# Altium schematic sheet Ethernet.SchDoc(Ethernet)
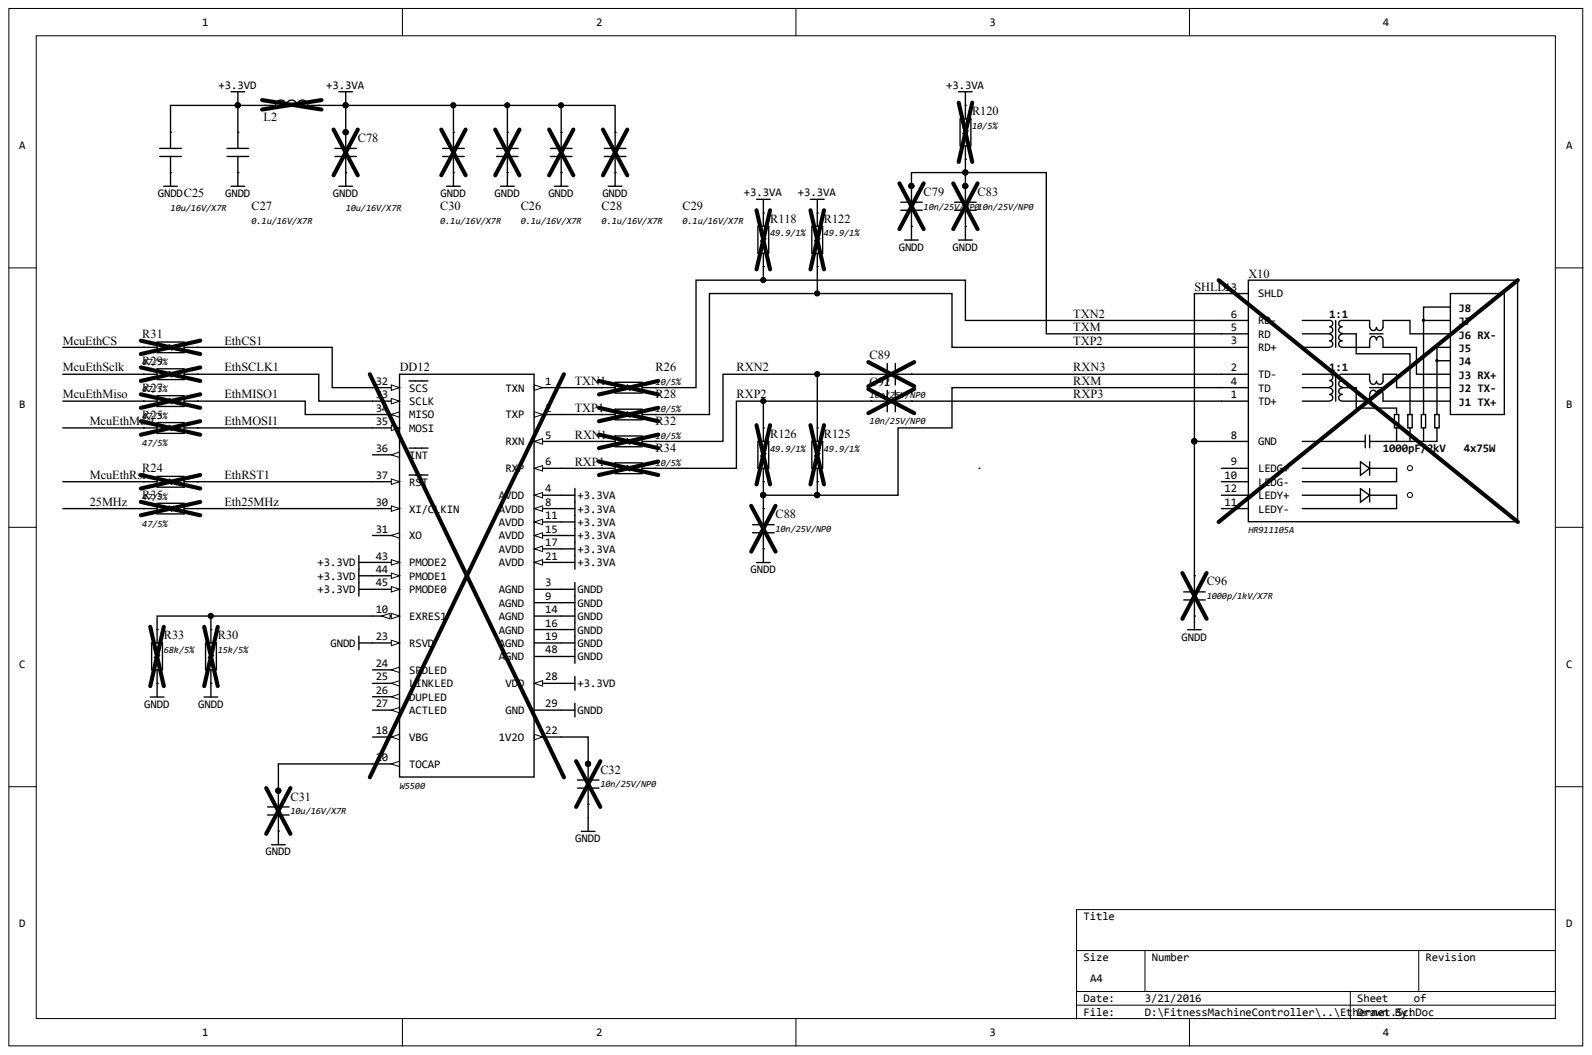
[diagram p0: Ethernet.SchDoc]

## Parts
C25  10u/16V/X7R
C26  0.1u/16V/X7R
C27  0.1u/16V/X7R
C28  0.1u/16V/X7R
C29  0.1u/16V/X7R
C30  0.1u/16V/X7R
C31  10u/16V/X7R
C32  10n/25V/NP0
C78  10u/16V/X7R
C79  10n/25V/NP0
C83  10n/25V/NP0
C88  10n/25V/NP0
C89  10n/25V/NP0
C92  10n/25V/NP0
C96  1000p/1kV/X7R
DD12  W5500
L2  FerriteFilterBLM18  (value not drawn)
R24  47/5%
R25  47/5%
R26  10/5%
R27  47/5%
R28  10/5%
R29  47/5%
R30  15k/5%
R31  47/5%
R32  10/5%
R33  68k/5%
R34  10/5%
R35  47/5%
R118  49.9/1%
R120  10/5%
R122  49.9/1%
R125  49.9/1%
R126  49.9/1%
X10  HR911105A

## Nets
+3.3VA: C26.2 C28.2 C29.2 C30.2 C78.2 DD12.4(AVDD) DD12.8(AVDD) DD12.11(AVDD) DD12.15(AVDD) DD12.17(AVDD) DD12.21(AVDD) L2.2 R118.2 R120.2 R122.2
+3.3VD: C25.2 C27.2 DD12.28(VDD) DD12.43(PMODE2) DD12.44(PMODE1) DD12.45(PMODE0) L2.1
GNDD: C25.1 C26.1 C27.1 C28.1 C29.1 C30.1 C31.1 C32.1 C78.1 C79.1 C83.1 C88.1 C96.1 DD12.3(AGND) DD12.9(AGND) DD12.14(AGND) DD12.16(AGND) DD12.19(AGND) DD12.23(RSVD) DD12.29(GND) DD12.48(AGND) R30.1 R33.1
25MHZ: R35.1
ETH25MHZ: DD12.30(XI/CLKIN) R35.2
ETHMOSI1: DD12.35(MOSI) R25.2
ETHRST1: DD12.37(RST) R24.2
MCUETHCS: R31.1
MCUETHMISO: R27.1
MCUETHMOSI: R25.1
MCUETHRST: R24.1
MCUETHSCLK: R29.1
RXM: C88.2 R125.1 R126.1 X10.4(TD)
RXN1: DD12.5(RXN) R32.2
RXN2: C89.2 R32.1 R125.2
RXN3: C89.1 X10.2(TD-)
RXP1: DD12.6(RXP) R34.2
RXP2: C92.2 R34.1 R126.2
RXP3: C92.1 X10.1(TD+)
SHLD: C96.2 X10.8(GND) X10.13(SHLD)
TXM: C79.2 C83.2 R120.1 X10.5(RD)
TXN1: DD12.1(TXN) R26.2
TXN2: R26.1 R118.1 X10.6(RD-)
TXP1: DD12.2(TXP) R28.2
TXP2: R28.1 R122.1 X10.3(RD+)
NetC31_2: C31.2 DD12.20(TOCAP)
NetC32_2: C32.2 DD12.22(1V2O)
NetDD12_10: DD12.10(EXRES1) R30.2 R33.2
NetDD12_32: DD12.32(SCS) R31.2
NetDD12_33: DD12.33(SCLK) R29.2
NetDD12_34: DD12.34(MISO) R27.2
ETHCS1:
ETHMISO1:
ETHSCLK1:
N00174:

Not connected: DD12 18(VBG) 24(SPDLED) 25(LINKLED) 26(DUPLED) 27(ACTLED) 31(XO) 36(INT); X10 9(LEDG+) 10(LEDG-) 11(LEDY-) 12(LEDY+)

## Library data of the parts
C25 C31 C78 C96 = (no symbol name): ComponentDescriptionRUS: Конденсатор SMD 1206; Analog: нет; NumberSolderPointsSMD: 0; NumberSolderPointsTHT: 0
C26-C30 C32 C79 C83 C88 C89 C92 = (no symbol name): ComponentDescriptionRUS: Конденсатор SMD 0603; Analog: нет; NumberSolderPointsSMD: 0; NumberSolderPointsTHT: 0
DD12 = (no symbol name): ComponentDescriptionRUS: Микросхема TQFP48
L2 = (no symbol name): ComponentDescriptionRUS: Фильтр SMD 0603; Analog: нет; NumberSolderPointsSMD: 0; NumberSolderPointsTHT: 0
R24-R35 R118 R120 R122 R125 R126 = (no symbol name): ComponentDescriptionRUS: Резистор SMD 0603; Analog: нет; NumberSolderPointsSMD: 0; NumberSolderPointsTHT: 0
X10 = (no symbol name): ComponentDescriptionRUS: Разъем; Analog: нет; NumberSolderPointsSMD: 0; NumberSolderPointsTHT: 0
